# Altium schematic sheet Sensors.SchDoc
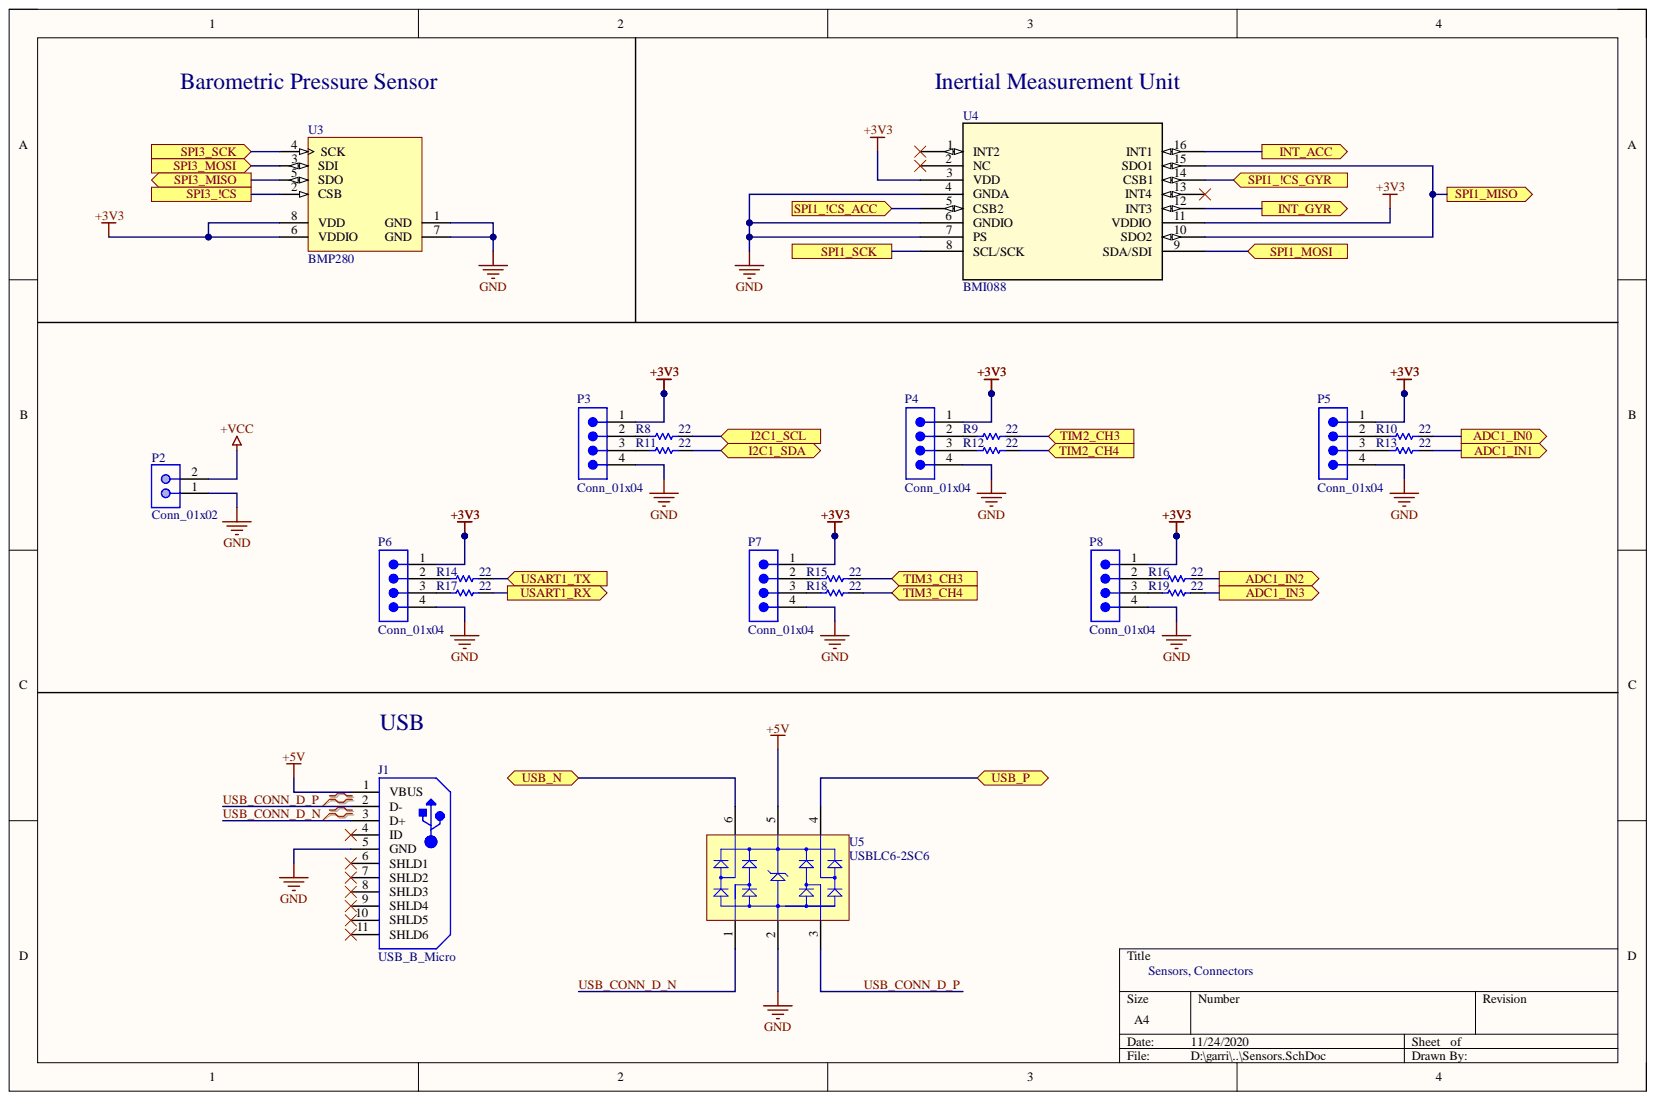
[diagram p0: Sensors.SchDoc]

## Parts
J1  USB_B_Micro  [MOLX-105133-0001_V]
P2  Conn_01x02  [HDRV10W90P254_1X2_1270X483X840P]
P3  Conn_01x04  [HDRV10W90P254_1X4_1270X483X840P]
P4  Conn_01x04  [HDRV10W90P254_1X4_1270X483X840P]
P5  Conn_01x04  [HDRV10W90P254_1X4_1270X483X840P]
P6  Conn_01x04  [HDRV10W90P254_1X4_1270X483X840P]
P7  Conn_01x04  [HDRV10W90P254_1X4_1270X483X840P]
P8  Conn_01x04  [HDRV10W90P254_1X4_1270X483X840P]
R8  22  [Resistor 0402]
R9  22  [Resistor 0402]
R10  22  [Resistor 0402]
R11  22  [Resistor 0402]
R12  22  [Resistor 0402]
R13  22  [Resistor 0402]
R14  22  [Resistor 0402]
R15  22  [Resistor 0402]
R16  22  [Resistor 0402]
R17  22  [Resistor 0402]
R18  22  [Resistor 0402]
R19  22  [Resistor 0402]
U3  BMP280  [8-PIN-METAL-LID-LGA]
U4  BMI088  [BMI088]
U5  USBLC6-2SC6  [SOT95P280X145-6N]

## Ports
ADC1_IN0, ADC1_IN1, ADC1_IN2, ADC1_IN3, I2C1_SCL, I2C1_SDA, INT_ACC, INT_GYR, SPI1_!CS_ACC, SPI1_!CS_GYR, SPI1_MISO, SPI1_MOSI, SPI1_SCK, SPI3_!CS, SPI3_MISO, SPI3_MOSI, SPI3_SCK, TIM2_CH3, TIM2_CH4, TIM3_CH3, TIM3_CH4, USART1_RX, USART1_TX, USB_N, USB_P

## Nets
+3V3: P3.1 P4.1 P5.1 P6.1 P7.1 P8.1 U3.6(VDDIO) U3.8(VDD) U4.3(VDD) U4.11(VDDIO)
+5V: J1.1(VBUS) U5.5
+VCC: P2.2
GND: J1.5(GND) P2.1 P3.4 P4.4 P5.4 P6.4 P7.4 P8.4 U3.1(GND) U3.7(GND) U4.4(GNDA) U4.6(GNDIO) U4.7(PS) U5.2
ADC1_IN0: R10.1 ; port ADC1_IN0
ADC1_IN1: R13.1 ; port ADC1_IN1
ADC1_IN2: R16.1 ; port ADC1_IN2
ADC1_IN3: R19.1 ; port ADC1_IN3
I2C1_SCL: R8.1 ; port I2C1_SCL
I2C1_SDA: R11.1 ; port I2C1_SDA
INT_ACC: U4.16(INT1) ; port INT_ACC
INT_GYR: U4.12(INT3) ; port INT_GYR
SPI1_!CS_ACC: U4.5(CSB2) ; port SPI1_!CS_ACC
SPI1_!CS_GYR: U4.14(CSB1) ; port SPI1_!CS_GYR
SPI1_MISO: U4.10(SDO2) U4.15(SDO1) ; port SPI1_MISO
SPI1_MOSI: U4.9(SDA/SDI) ; port SPI1_MOSI
SPI1_SCK: U4.8(SCL/SCK) ; port SPI1_SCK
SPI3_!CS: U3.2(CSB) ; port SPI3_!CS
SPI3_MISO: U3.5(SDO) ; port SPI3_MISO
SPI3_MOSI: U3.3(SDI) ; port SPI3_MOSI
SPI3_SCK: U3.4(SCK) ; port SPI3_SCK
TIM2_CH3: R9.1 ; port TIM2_CH3
TIM2_CH4: R12.1 ; port TIM2_CH4
TIM3_CH3: R15.1 ; port TIM3_CH3
TIM3_CH4: R18.1 ; port TIM3_CH4
USART1_RX: R17.1 ; port USART1_RX
USART1_TX: R14.1 ; port USART1_TX
USB_CONN_D_N: J1.3(D+) U5.1
USB_CONN_D_P: J1.2(D-) U5.3
USB_N: U5.6 ; port USB_N
USB_P: U5.4 ; port USB_P
NetP3_2: P3.2 R8.2
NetP3_3: P3.3 R11.2
NetP4_2: P4.2 R9.2
NetP4_3: P4.3 R12.2
NetP5_2: P5.2 R10.2
NetP5_3: P5.3 R13.2
NetP6_2: P6.2 R14.2
NetP6_3: P6.3 R17.2
NetP7_2: P7.2 R15.2
NetP7_3: P7.3 R18.2
NetP8_2: P8.2 R16.2
NetP8_3: P8.3 R19.2

Not connected: J1 4(ID) 6(SHLD1) 7(SHLD2) 8(SHLD3) 9(SHLD4) 10(SHLD5) 11(SHLD6); U4 1(INT2) 2(NC) 13(INT4)

## Library data of the parts
J1 = USB_B_Micro: Micro USB B Type Receptacle, -55 to 85 degC, 5-Pin SMD, RoHS, Tape and Reel; Manufacturer: Amphenol FCI; Manufacturer Part Number: 10118193-0001LF; Brand: FCI; Connector Type: MicroUSBTypeBreceptacle; Current Rating: 1.8A; Gender: Female; Insulation Resistance: 1000MOhms; Mounting Angle: Right; Number of Contacts: 5Contact; Color: Black; Component Kind: Standard; Contact Material: CopperAlloy; Contact Plating: Gold; Datasheet URL: http://datasheet.octopart.com/10118193-0001LF-FCI-datasheet-55953155.pdf; Datasheet Version: Rev. C, 05/2015; Factory Pack Quantity: 1200; Flammability Rating: UL94V-0; Library Name: F4_Flight_Controller.SCHLIB; Manufacturer URL: http://www.fci.com/; Operating Temperature Range: -55Cto+85C; Packaging: Reel; Product: MicroUSBTypeBConnectors; Product Category: USBConnectors; RoHS: Details; Standard: USB2.0; Termination Style: SMD/SMT
P2 = Male Header 01x02: Male Header, Pitch 2.54 mm, 1 x 2 Position, Height 8.21 mm, Tail Length 3.05 mm, -65 to 105 degC, RoHS, Bulk; Manufacturer: TE Connectivity; Manufacturer Part Number: 5-146280-2; Approvals: CSA; Board Standoff: No(Without); Case\/Package: 100; Current Rating: 3A; High Speed Serial Data Connector: No; High Temperature Housing: Yes; Mating Post Length: 0.23inch; Max Current Rating: 5A; Number of Contacts: 2; PCB Mount Retention: Without; PCB Mounting Orientation: Vertical; PCB Thickness: 0.063inch; Pitch: 2.54mm; Colour: Black; Component Kind: Standard; Connector Type: Header; Contact Gender: Male; Contact Material: CopperAlloy; Contact Mating Length: 0.23inch; Contact Plating: Matte; Contact Protection: No(Without); Contact Shape: Square; Datasheet URL: http://www.te.com/commerce/DocumentDelivery/DDEController?Action=showdoc&DocId=Customer+Drawing%7F146280%7FH1%7Fpdf%7FEnglish%7FENG_CD_146280_H1.pdf%7F5-146280-2; Datasheet Version: Rev. H1, 08/2016; Depth: 2.34mm; Flammability Rating: UL94V-0; Gasket: No(Without); Housing Colour: Black; Housing Material: Polymer; Keyed: No; Length: 4.67mm; Library Name: F4_Flight_Controller.SCHLIB; Manufacturer URL: http://www.te.com/; Mating Alignment: No(Without); Mating Connector Lock: No(Without); Max Operating Temperature: 105degC; Min Operating Temperature: -65degC; Mount: ThroughHole; Mounting Technology: ThroughHole; Number of Positions: 2; Number of Rows: 1; Orientation: Straight; Package Description: Male Header, Pitch 2.54 mm, 1 x 2 Position, Height 8.21 mm, Tail Length 3.05 mm; Package Quantity: 500; Package Reference: 5-146280-2; Packaging: Carton; Panel Mount Retention: No(Without); Radiation Hardening: No; RoHSCompliant: true; Row Spacing: 2.54mm; Selectively Loaded: No(Without); Stabilizers: No(Without); Termination: ThroughHole; Termination Post Length: 0.12inch
P3-P8 = Male Header 01x04: Horizontal Surface Mount Header, -55 to 125 degC, 2 mm Pitch, 4-Pin, Male, RoHS; Manufacturer: Samtec; Manufacturer Part Number: MMT-104-01-L-SH; Pitch: 2 mm; Component Kind: Standard; ComponentLink1Description: Manufacturer URL; ComponentLink1URL: http://www.samtec.com; ComponentLink2Description: Datasheet; ComponentLink2URL: http://d2a072wq521u33.cloudfront.net/catalog_english//MMT_SM.PDF; ComponentLink3Description: Package Specification; ComponentLink3URL: http://www.samtec.com/documents/webfiles/cpdf/MMT-1XX-XX-XX-XX-XX-XXX-X-XX-MKT.pdf; DatasheetVersion: F-214; Library Name: F4_Flight_Controller.SCHLIB; Mounting Technology: Surface Mount; Orientation: Horizontal; PackageDescription: 2mm Horizontal Terminal Surface Mount Strip ASSEM; PackageReference: MMT-104-01-X-SH; PackageVersion: CY, 12/2000; PartNumber: MMT-104-01-L-SH; RoHS: True; Temperature Range: -55 to 125 degC
R8-R19 = Resistor 0402: Chip Resistor 0402; Manufacturer: Vishay; Manufacturer Part Number: CRCW0402120RFKED; Component Kind: Standard; Library Name: F4_Flight_Controller.SCHLIB; Part Number: CRCW0402120RFKED
U3 = BMP280: Digital Pressure Sensor,; Manufacturer: Bosch; Component Kind: Standard; Datasheet URL: http://datasheet.octopart.com/BMP280-Bosch-datasheet-41546027.pdf; Datasheet Version: Rev. 1.14, 05/2015; Library Name: F4_Flight_Controller.SCHLIB; Manufacturer Part Number: BMP280; Manufacturer URL: http://www.bosch-sensortec.com
U4 = BMI088: Inertial Measurement Unit BOSCH; Built_By: EMA_Matt; SourceLibrary: Updates_to_database_2020-10-21; Component Kind: Standard; Library Name: F4_Flight_Controller.SCHLIB; Manufacturer_Part_Number: BMI088; RefDes: RefDes; Revision: 1; Type: DEV; Vendor: Bosch; Copyright: Copyright (C) 2018 Accelerated Designs. All rights reserved
U5 = ESD protection: Very low capacitance ESD protection, 3.5 pF, -40 to 125 degC, 6-Pin SOT23, RoHS, Tape and Reel; Manufacturer: STMicroelectronics; Capacitance Value: 3.5pF; Clamping Voltage: 17V; Configuration: Dual; Direction Type: Uni-Directional; Leakage Current: 0.15uA; Number of Elements: 1; Peak Pulse Current: 5A; Product Depth (mm): 1.75mm; Product Height (mm): 1.3mm; Product Length (mm): 3.05mm; Rad Hardened: No; Reverse Breakdown Voltage: 6V; Reverse Stand-off Voltage: 5V; Suppressor Type: DiodeArrays; Test Current (It): 1mA; Clamping Voltage (Max): 17V; Component Kind: Standard; Datasheet URL: http://www.st.com/st-web-ui/static/active/en/resource/technical/document/datasheet/CD00050750.pdf; Datasheet Version: Rev. 5, 10/2011; Leakage Current (Max): 0.15uA; Library Name: F4_Flight_Controller.SCHLIB; Manufacturer Part Number: USBLC6-2SC6; Manufacturer URL: http://www.st.com/; Mounting: SurfaceMount; Mounting Style: SurfaceMount; Operating Temp Range: -40Cto125C; Operating Temperature Classification: Automotive; Operating Temperature Max Deg. C: 125C; Operating Temperature Min Deg. C: -40C; Package / Case: SOT-23; Package Description: 6-Pin Small Outline Transistor, Body 2.925 x 1.625 mm, Pitch 0.95 mm; Package Reference: SOT23-6L; Packaging: TapeandReel; Pin Count: 6; Polarity: Uni-Directional; Working Voltage: 5V; Working Voltage (Max): 5V
